# Altium schematic sheet Sheet1.SchDoc
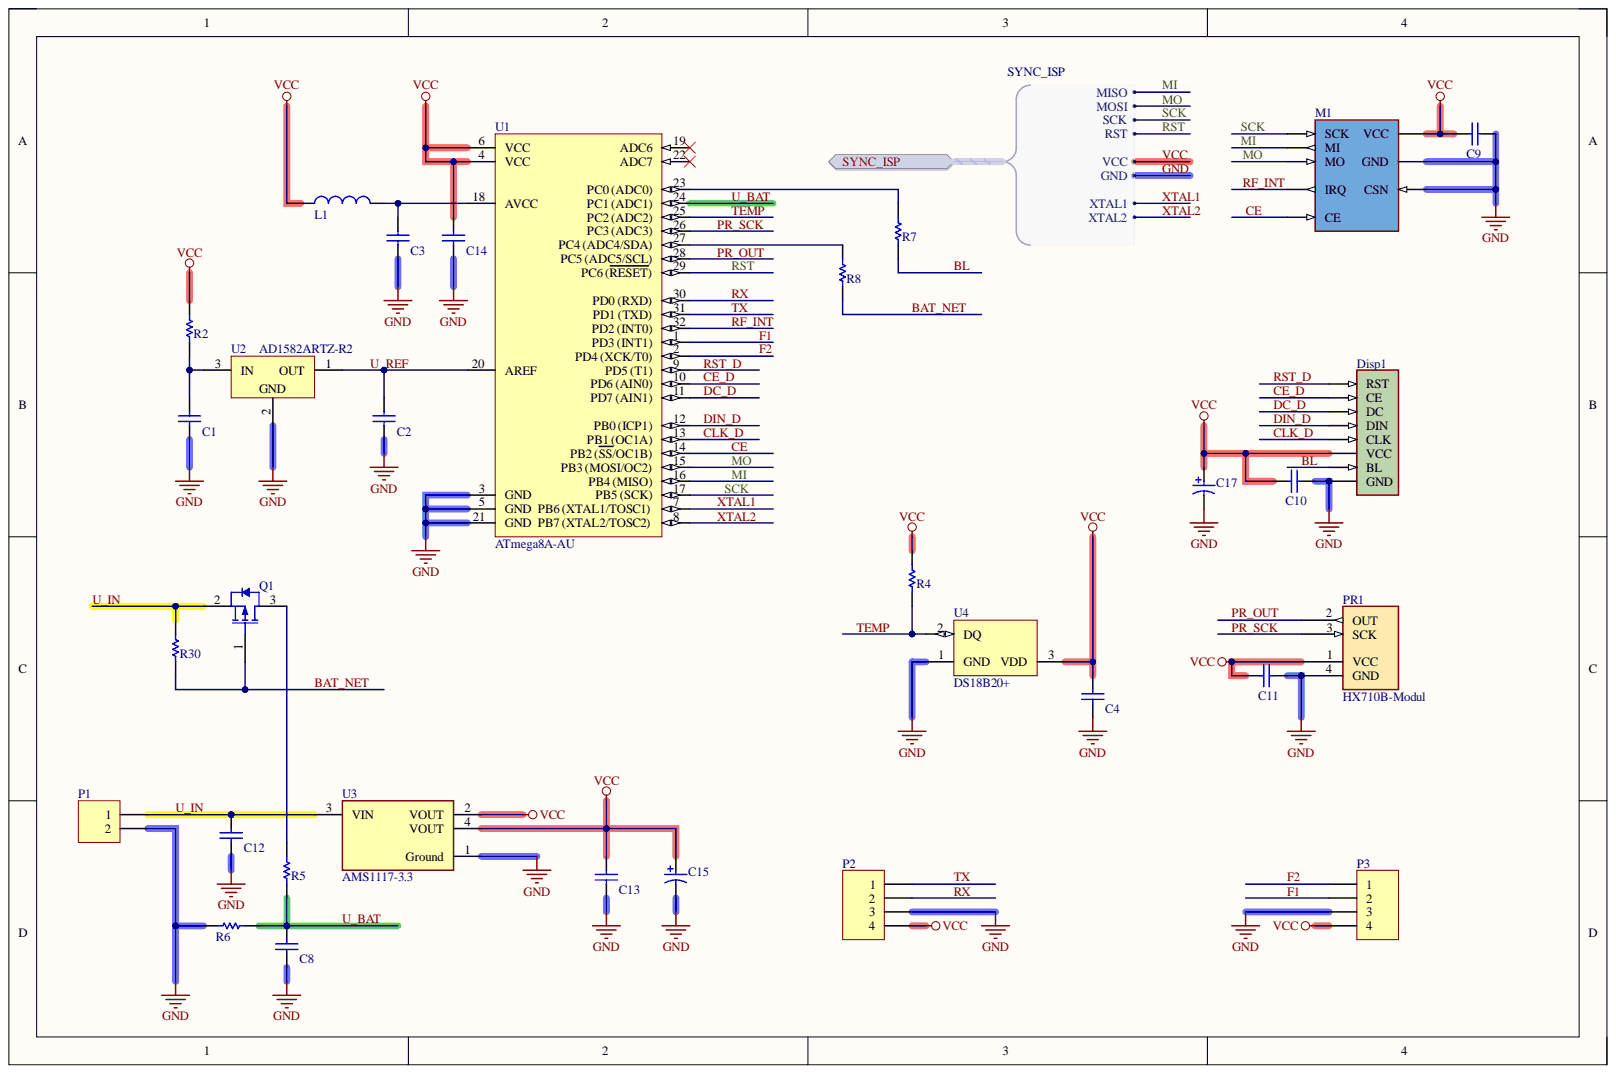
[diagram p0: Sheet1.SchDoc]

## Parts
C1  CAP 270pF 100V 0805(2012)  [CAPC0805(2012)100_N]  (value not drawn)
C2  CAP 270pF 100V 0805(2012)  [CAPC0805(2012)100_N]  (value not drawn)
C3  CAP 270pF 100V 0805(2012)  [CAPC0805(2012)100_N]  (value not drawn)
C4  CAP 270pF 100V 0805(2012)  [CAPC0805(2012)100_N]  (value not drawn)
C8  CAP 270pF 100V 0805(2012)  [CAPC0805(2012)100_N]  (value not drawn)
C9  CAP 270pF 100V 0805(2012)  [CAPC0805(2012)100_N]  (value not drawn)
C10  CAP 270pF 100V 0805(2012)  [CAPC0805(2012)100_N]  (value not drawn)
C11  CAP 270pF 100V 0805(2012)  [CAPC0805(2012)100_N]  (value not drawn)
C12  CAP 270pF 100V 0805(2012)  [CAPC0805(2012)100_N]  (value not drawn)
C13  CAP 270pF 100V 0805(2012)  [CAPC0805(2012)100_N]  (value not drawn)
C14  CAP 270pF 100V 0805(2012)  [CAPC0805(2012)100_N]  (value not drawn)
C15  TPSD226K025R0200  [AVX-7343-31_L]  (value not drawn)
C17  TPSD226K025R0200  [AVX-7343-31_L]  (value not drawn)
Disp1  NOKIA5110  [NOKIA_5110]  (value not drawn)
L1  742792093  [SMD-0805]  (value not drawn)
M1  nRF24L01  [nRF24L01]  (value not drawn)
P1  Header 2  [HDR1X2]  (value not drawn)
P2  Header 4  [HDR1X4]  (value not drawn)
P3  Header 4  [HDR1X4]  (value not drawn)
PR1  HX710B-Modul  [HX710B-Modul]
Q1  IRLML6402TRPBF  [INTR-SOT-23-3_V]  (value not drawn)
R2  CRCW08050000Z0EA  [RESC2013X50X30LL20T20]  (value not drawn)
R4  CRCW08050000Z0EA  [RESC2013X50X30LL20T20]  (value not drawn)
R5  CRCW08050000Z0EA  [RESC2013X50X30LL20T20]  (value not drawn)
R6  CRCW08050000Z0EA  [RESC2013X50X30LL20T20]  (value not drawn)
R7  CRCW08050000Z0EA  [RESC2013X50X30LL20T20]  (value not drawn)
R8  CRCW08050000Z0EA  [RESC2013X50X30LL20T20]  (value not drawn)
R30  CRCW08050000Z0EA  [RESC2013X50X30LL20T20]  (value not drawn)
U1  ATmega8A-AU  [32A_N]
U2  AD1582ARTZ-R2  [ADI-RT-3_N]
U3  AMS1117-3.3  [FP-AMS1117-IPC_C]
U4  DS18B20+  [Q3+1]

## Ports
SYNC_ISP, SYNC_ISP.GND, SYNC_ISP.MISO, SYNC_ISP.MOSI, SYNC_ISP.RST, SYNC_ISP.SCK, SYNC_ISP.VCC, SYNC_ISP.XTAL1, SYNC_ISP.XTAL2

## Nets
BAT_NET: Q1.1 R8.1 R30.2
BL: Disp1.7(BL) R7.1
CE: M1.3(CE) U1.14(PB2_(SS/OC1B))
CE_D: Disp1.2(CE) U1.10(PD6_(AIN0))
CLK_D: Disp1.5(CLK) U1.13(PB1_(OC1A))
DC_D: Disp1.3(DC) U1.11(PD7_(AIN1))
DIN_D: Disp1.4(DIN) U1.12(PB0_(ICP1))
F1: P3.2 U1.1(PD3_(INT1))
F2: P3.1 U1.2(PD4_(XCK/T0))
GND: C1.1 C2.1 C3.1 C4.1 C8.1 C9.1 C10.1 C11.1 C12.1 C13.1 C14.1 C15.2 C17.2 Disp1.8(GND) M1.1(GND) M1.4(CSN) P1.2 P2.3 P3.3 PR1.4(GND) R6.1 U1.3(GND) U1.5(GND) U1.21(GND) U2.2 U3.1(Ground) U4.1 ; port SYNC_ISP
MI: M1.7(MI) U1.16(PB4_(MISO)) ; port SYNC_ISP
MO: M1.6(MO) U1.15(PB3_(MOSI/OC2)) ; port SYNC_ISP
PR_OUT: PR1.2(OUT) U1.28(PC5_(ADC5/SCL))
PR_SCK: PR1.3(SCK) U1.26(PC3_(ADC3))
RF_INT: M1.8(IRQ) U1.32(PD2_(INT0))
RST: U1.29(PC6_(RESET)) ; port SYNC_ISP
RST_D: Disp1.1(RST) U1.9(PD5_(T1))
RX: P2.2 U1.30(PD0_(RXD))
SCK: M1.5(SCK) U1.17(PB5_(SCK)) ; port SYNC_ISP
TEMP: R4.1 U1.25(PC2_(ADC2)) U4.2
TX: P2.1 U1.31(PD1_(TXD))
U_BAT: C8.2 R5.1 R6.2 U1.24(PC1_(ADC1))
U_IN: C12.2 P1.1 Q1.2 R30.1 U3.3(VIN)
U_REF: C2.2 U1.20(AREF) U2.1
VCC: C4.2 C9.2 C10.2 C11.2 C13.2 C14.2 C15.1 C17.1 Disp1.6(VCC) L1.1 M1.2(VCC) P2.4 P3.4 PR1.1(VCC) R2.2 R4.2 U1.4(VCC) U1.6(VCC) U3.2(VOUT) U3.4(VOUT) U4.3 ; port SYNC_ISP
XTAL1: U1.7 ; port SYNC_ISP
XTAL2: U1.8 ; port SYNC_ISP
NetC1_2: C1.2 R2.1 U2.3
NetC3_2: C3.2 L1.2 U1.18(AVCC)
NetQ1_3: Q1.3 R5.2
NetR7_2: R7.2 U1.23(PC0_(ADC0))
NetR8_2: R8.2 U1.27(PC4_(ADC4/SDA))

Not connected: U1 19(ADC6) 22(ADC7)

## Library data of the parts
C1 = CAP: CAP 270pF 100V ±1% 0805 (2012 Metric) Thickness 1mm SMD; Case-EIA: 0805; Case-Metric: 2012; Tolerance: Â±1%; Value: 10n; Component Kind: Standard; Library Name: RF_Monitor_1.SCHLIB; Max Thickness: 1 mm; Rated Voltage: 100 V; Technology: SMT
C2-C4 C8-C14 = CAP: CAP 270pF 100V ±1% 0805 (2012 Metric) Thickness 1mm SMD; Case-EIA: 0805; Case-Metric: 2012; Tolerance: Â±1%; Value: 100n; Component Kind: Standard; Library Name: RF_Monitor_1.SCHLIB; Max Thickness: 1 mm; Rated Voltage: 100 V; Technology: SMT
C15 C17 = CMP-2000-07301-1: Tantalum Capacitor, 22 uF, +/- 10%, 25 V, -55 to 125 degC, 2-Pin SMD, RoHS, Tape and Reel; Manufacturer: AVX; Capacitance: 22000000pF; Case Style: Molded; DCL: 5.5uA; DELETED: Compliant; DF: 6%; ESR: 0.2ohm; Insulation: NotRequired; Lead Spacing (nom): NotRequiredmm; Polarity: Polar; Product Depth (mm): 4.3mm; Product Height (mm): 2.9mm; Product Length (mm): 7.3mm; Rad Hardened: No; Voltage: 25VDC; Case Code: D; Component Kind: Standard; Construction: SMTChip; Datasheet URL: https://datasheet.ciiva.com/11793/0900766b80066cb4-11793194.pdf; Datasheet Version: 02/2004; Failure Rate: NotRequired; Length W/Weld: NotRequiredmm; Length W/Weld (max): NotRequiredmm; Library Name: Altium Content Vault; Manufacturer URL: http://www.avx.com/; Mounting Style: SurfaceMount; Mounting Technology: SurfaceMount; Operating Temp Range: -55Cto125C; Package / Case: 7343-31; Package Description: 2-Pin Surface Mount Device, Body 7.3 x 4.35 mm; Package Reference: 7343-31; Packaging: TapeandReel; Product Diameter (mm): NotRequiredmm; Seal: NotRequired; Seated Plane Height: NotRequiredmm; Tolerance (+ or -): 10%; Wire Form: NotRequired
L1 = CMP-0220-00169-1: SMD EMI Suppression Ferrite Bead WE-CBF, Z = 2200 Ohm; Component Kind: Standard; ComponentLink1Description: Manufacturer Link; ComponentLink1URL: http://www.we-online.com; ComponentLink2Description: Datasheet; ComponentLink2URL: http://www.we-online.de/katalog/media/pdf/742792093.pdf; DatasheetVersion: Dec-2005; Impedance: 2200 Ohm; Library Name: Altium Content Vault; PackageDescription: Chip Inductor, 2-Leads, Body 2.0 x 1.2 mm; PackageReference: SMD-0805; PackageVersion: 2005
P1 = Header 2: Header, 2-Pin; Component Kind: Standard; LatestRevisionDate: 17-Jul-2002; LatestRevisionNote: Re-released for DXP Platform.; Library Name: Miscellaneous Connectors.IntLib; Signal Integrity: Connector; Publisher: Altium Limited
P2 P3 = Header 4: Header, 4-Pin; Component Kind: Standard; LatestRevisionDate: 17-Jul-2002; LatestRevisionNote: Re-released for DXP Platform.; Library Name: Miscellaneous Connectors.IntLib; Signal Integrity: Connector; Publisher: Altium Limited
Q1 = CMP-2000-04987-1: HEXFET(R) Power P-Channel MOSFET, -20 V, -3.7 A, -55 to 150 degC, 3-Pin SOT-23, RoHS, Tape and Reel; Manufacturer: International Rectifier; Drain-Source On Resistance-Max: 0.065O; Drain-to-Source Voltage [Vdss]: 20V; Qg Gate Charge: 8nC; Rated Power Dissipation: 1.3W; Channel Type: P-Channel; Component Kind: Standard; Datasheet URL: http://datasheet.octopart.com/IRLML6402TRPBF-International-Rectifier-datasheet-93882.pdf; Datasheet Version: Feb-05; Library Name: Altium Content Vault; Manufacturer URL: http://internationalrectifier.com/; Package Description: 3-Pin Small Outline Transistor, Body 2.92 x 1.3 mm, Pitch 0.95 mm; Package Reference: SOT-23-3
R2 = CMP-2001-04896-1: Manufacturer: Vishay; Value: 4k7; Component Kind: Standard; Library Name: RF_Monitor_1.SCHLIB; Manufacturer Part Number: CRCW08050000Z0EA; Part Number: CRCW08050000Z0EA
R4-R8 R30 = CMP-2001-04896-1: Manufacturer: Vishay; Component Kind: Standard; Library Name: RF_Monitor_1.SCHLIB; Manufacturer Part Number: CRCW08050000Z0EA; Part Number: CRCW08050000Z0EA
U1 = CMP-0095-00219-2: 8-bit AVR Microcontroller, 2.7-5.5V, 16MHz, 8KB Flash, 512 Bytes EEPROM, 1KB SRAM, 32-pin TQFP, Industrial Grade (-40°C to 85°C); Manufacturer: Microchip / Atmel; Code_JEDEC: MS-026-ABA; Component Kind: Standard; ComponentLink1Description: Manufacturer URL; ComponentLink1URL: http://www.atmel.com/; ComponentLink2Description: ATmega8A Datasheet; ComponentLink2URL: http://www.atmel.com/dyn/resources/prod_documents/doc8159.pdf; ComponentLink3Description: ATmega8A Summary; ComponentLink3URL: http://www.atmel.com/dyn/resources/prod_documents/8159S.pdf; DatasheetVersion: revD, Feb-2011; Library Name: RF_Monitor_1.SCHLIB; Manufacturer Part Number: ATMEGA8A-AU; PackageDescription: 32-Lead, 7x7x1.0 mm Body, 0.8mm Lead Pitch, Thin Profile Plastic Quad Flat Package (TQFP); PackageReference: 32A; PackageVersion: revC, Oct-2010; RevisionNote: DatasheetVersion & PackageVersion updated. URLs added.
U2 = CMP-0784-00461-1: Micropower, Precision Series Mode Voltage Reference, 2.5 V Output, 2.7 to 12 V Supply, Industrial, 3-pin SOT23 (RT-3), Reel; Manufacturer: Analog Devices; Ref Out TC (ppm/C): 40; Vout: 2.5V; Code_JEDEC: TO-236-AB; Component Kind: Standard; ComponentLink1Description: Manufacturer URL; ComponentLink1URL: http://www.analog.com/en/index.html; ComponentLink2Description: Datasheet; ComponentLink2URL: http://www.analog.com/static/imported-files/data_sheets/AD1582_1583_1584_1585.pdf; ComponentLink3Description: Package Specification; ComponentLink3URL: http:/www.analog.com/static/imported-files/packages/PKG_PDF/SOT-23-3 (RT)/RT_3.pdf; ComponentLink4Description: URL Link1; ComponentLink4URL: Product URL:http://www.analog.com/en/special-linear-functions/voltage-references/ad1582/products/product.html; DatasheetVersion: Rev. I; Library Name: Altium Content Vault; Mounting Technology: Surface Mount; Operating Temp Range: -40 to 125 degC; PackageDescription: 3-Pin Small Outline Transistor Package, Body 2.9 x 1.3 mm, Pitch 0.96 mm; PackageReference: RT-3; PackageVersion: 011909-C, 02/2009; Packing: Reel; PartNumber: AD1582ARTZ-R2
U3 = CMP-209535-000001-1: LDO Voltage Regulators 1A, 3.3V; Manufacturer: Advanced Monolithic Systems; Dropout Voltage: 1.1V; Max Junction Temp: +125°C; Max Power Dissipation: 1.2W; Max Supply Voltage: 15V; Nominal Supply Current: 5mA; Number Of Outputs: 1; Output Type: Fixed; Standoff Height: 0.64mm; Automotive: No; Category: IC; Component Kind: Standard; ComponentLink2Description: Datasheet; ComponentLink2URL: http://www.advanced-monolithic.com/pdf/ds1117.pdf; Device Class L1: Integrated Circuits (ICs); Device Class L2: Power Management ICs; Device Class L3: Voltage Regulators - Linear; Height: 1.8mm; Ipc Land Pattern Name: SOT229P700X180-4; Lead Free: Yes; Library Name: Altium Content Vault; Manufacturer Part Number: AMS1117-3.3; Octopart: https://octopart.com/ams1117-3.3-advanced+monolithic+systems-25899300; Output Current: 1A; Output Voltage: 3.3V; Package: AMS1117; RoHS: TRUE; Temperature: -40°C to +125°C; Temperature Range High: +125°C; Temperature Range Low: -40°C
U4 = CMP-0253-00003-1: Programmable Resolution Digital Thermometer, 1-Wire Interface, ±0.5°C Accuracy, 3-Pin TO92, -55°C to +125°C, Pb-Free; Code_JEDEC: TO-226-AA; Component Kind: Standard; ComponentLink1Description: Manufacturer URL; ComponentLink1URL: http://www.maxim-ic.com; ComponentLink2Description: Datasheet; ComponentLink2URL: http://datasheets.maxim-ic.com/en/ds/DS18B20.pdf; DatasheetVersion: 2007; Library Name: Altium Content Vault; PackageDescription: TO-92 Package, Body Dia. 4.7mm; Leads 0.51 x 0.51 mm (max), Pb-Free; PackageReference: Q3+1; PackageVersion: revB, 2009
Disp1 = NOKIA5110: Component Kind: Standard; Description: NOKIA5110; LatestRevisionDate: 17-Jul-2002; LatestRevisionNote: Re-released for DXP Platform.; Library Name: RF_Monitor.SCHLIB; Signal Integrity: Connector; Publisher: Altium Limited
M1 = nRF24L01: Component Kind: Standard; Description: nRF24L01; LatestRevisionDate: 17-Jul-2002; LatestRevisionNote: Re-released for DXP Platform.; Library Name: RF_Monitor.SCHLIB; Signal Integrity: Connector; Publisher: Altium Limited
PR1 = HX710B-Modul: Component Kind: Standard; Description: HX710B-Modul; Library Name: RF_Monitor.SCHLIB
